# Altium schematic sheet FTDI.SchDoc(FTDI)
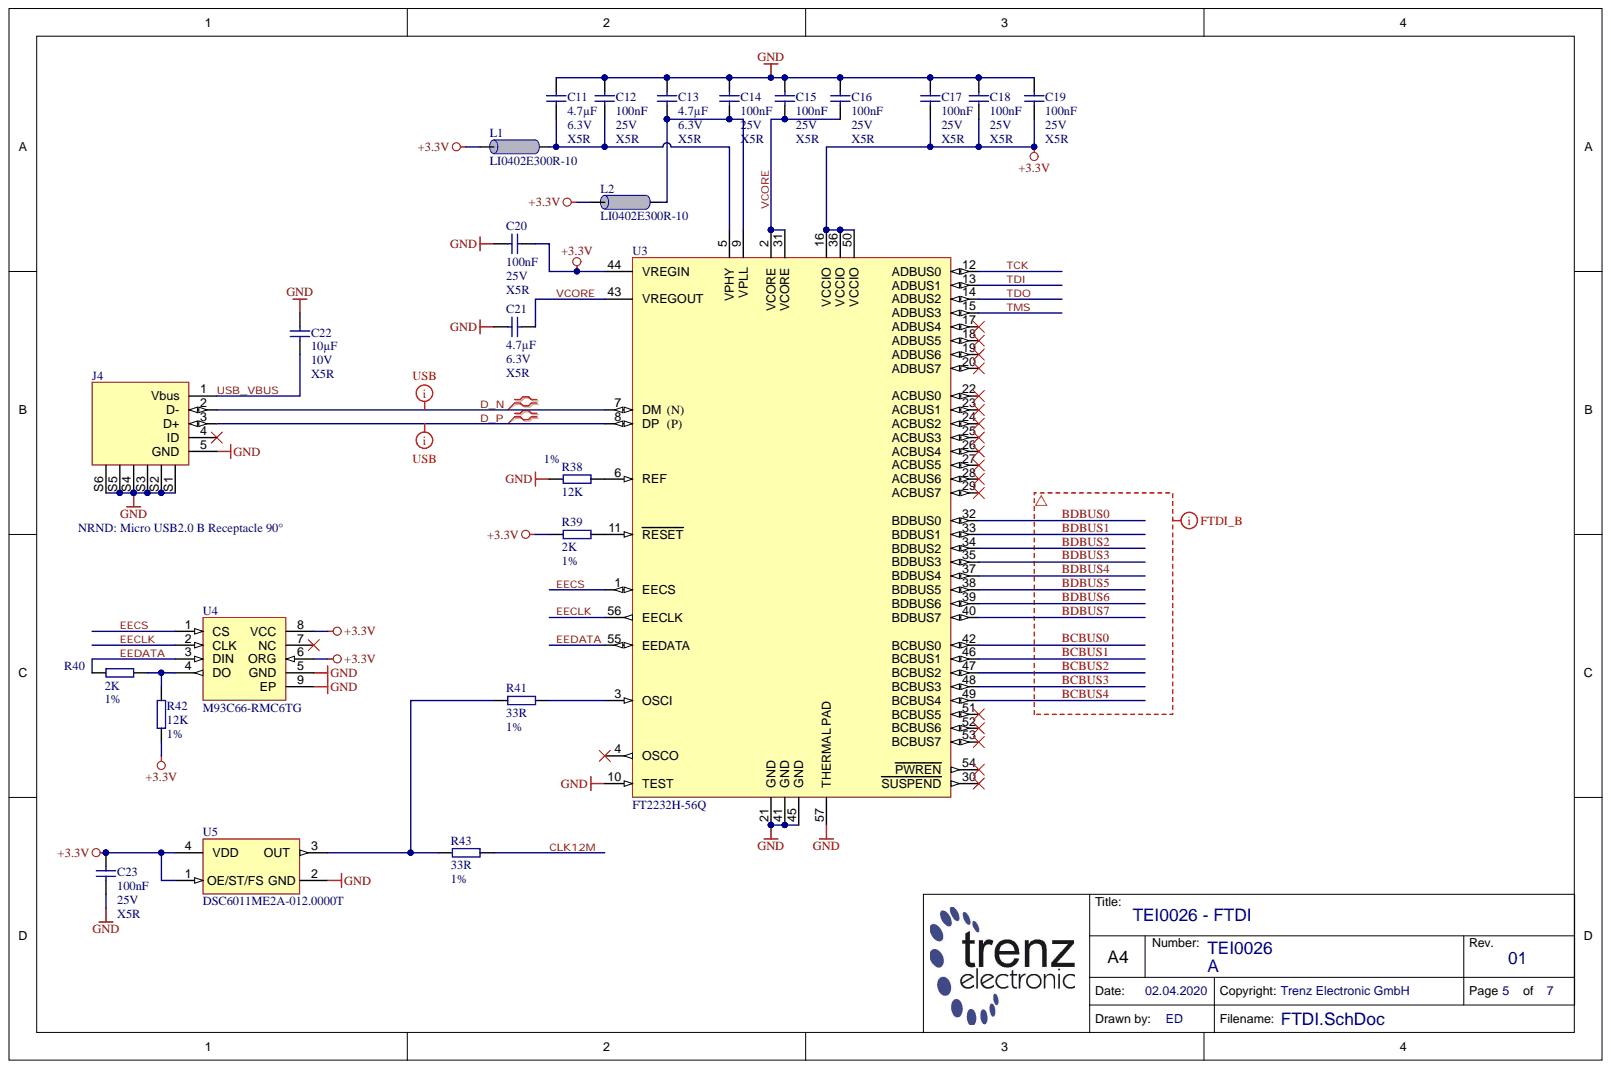
[diagram p0: FTDI.SchDoc]

## Parts
C11  4.7µF  Voltage: 6.3V  Technology: X5R  [CAPC1005X60N]
C12  100nF  Voltage: 25V  Technology: X5R  [CAPC1005X55N]
C13  4.7µF  Voltage: 6.3V  Technology: X5R  [CAPC1005X60N]
C14  100nF  Voltage: 25V  Technology: X5R  [CAPC1005X55N]
C15  100nF  Voltage: 25V  Technology: X5R  [CAPC1005X55N]
C16  100nF  Voltage: 25V  Technology: X5R  [CAPC1005X55N]
C17  100nF  Voltage: 25V  Technology: X5R  [CAPC1005X55N]
C18  100nF  Voltage: 25V  Technology: X5R  [CAPC1005X55N]
C19  100nF  Voltage: 25V  Technology: X5R  [CAPC1005X55N]
C20  100nF  Voltage: 25V  [CAPC1005X55N]
C21  4.7µF  Voltage: 6.3V  Technology: X5R  [CAPC1005X60N]
C22  10µF  Voltage: 10V  Technology: X5R  [CAPC2012X135N]
C23  100nF  Voltage: 25V  Technology: X5R  [CAPC1005X55N]
J4  NRND: Micro USB2.0 B Receptacle 90°  [105017-0001]
L1  LI0402E300R-10  [INDC1005X55N]
L2  LI0402E300R-10  [INDC1005X55N]
R38  12K  Tolerance: 1%  [RESC1005X40N]
R39  2K  Tolerance: 1%  [RESC1005X40N]
R40  2K  Tolerance: 1%  [RESC1005X40N]
R41  33R  Tolerance: 1%  [RESC1005X40N]
R42  12K  Tolerance: 1%  [RESC1005X40N]
R43  33R  Tolerance: 1%  [RESC1005X40N]
U3  FT2232H-56Q  [QFN50P800X800-57T610X610N]
U4  M93C66-RMC6TG  [UFDFPN8]
U5  DSC6011ME2A-012.0000T  [2016]

## Nets
+3.3V: C17.1 C18.1 C19.1 C20.2 C23.2 L1.2 L2.2 R39.1 R42.2 U3.16(VCCIO) U3.36(VCCIO) U3.44(VREGIN) U3.50(VCCIO) U4.6(ORG) U4.8(VCC) U5.1(OE/ST/FS) U5.4(VDD)
GND: C11.2 C12.2 C13.2 C14.2 C15.2 C16.2 C17.2 C18.2 C19.2 C20.1 C21.1 C22.1 C23.1 J4.5(GND) J4.S1 J4.S2 J4.S3 J4.S4 J4.S5 J4.S6 R38.1 U3.10(TEST) U3.21(GND) U3.41(GND) U3.45(GND) U3.57(THERMAL_PAD) U4.5(GND) U4.9(EP) U5.2(GND)
BCBUS0: U3.42(BCBUS0)
BCBUS1: U3.46(BCBUS1)
BCBUS2: U3.47(BCBUS2)
BCBUS3: U3.48(BCBUS3)
BCBUS4: U3.49(BCBUS4)
BDBUS0: U3.32(BDBUS0)
BDBUS1: U3.33(BDBUS1)
BDBUS2: U3.34(BDBUS2)
BDBUS3: U3.35(BDBUS3)
BDBUS4: U3.37(BDBUS4)
BDBUS5: U3.38(BDBUS5)
BDBUS6: U3.39(BDBUS6)
BDBUS7: U3.40(BDBUS7)
CLK12M: R43.2
D_N: J4.2(D-) U3.7(DM)
D_P: J4.3(D+) U3.8(DP)
EECLK: U3.56(EECLK) U4.2(CLK)
EECS: U3.1(EECS) U4.1(CS)
EEDATA: R40.1 U3.55(EEDATA) U4.3(DIN)
TCK: U3.12(ADBUS0)
TDI: U3.13(ADBUS1)
TDO: U3.14(ADBUS2)
TMS: U3.15(ADBUS3)
USB_VBUS: C22.2 J4.1(Vbus)
VCORE: C15.1 C16.1 C21.2 U3.2(VCORE) U3.31(VCORE) U3.43(VREGOUT)
NetC11_1: C11.1 C12.1 L1.1 U3.5(VPHY)
NetC13_1: C13.1 C14.1 L2.1 U3.9(VPLL)
NetR38_2: R38.2 U3.6(REF)
NetR39_2: R39.2 U3.11(RESET)
NetR40_2: R40.2 R42.1 U4.4(DO)
NetR41_1: R41.1 R43.1 U5.3(OUT)
NetR41_2: R41.2 U3.3(OSCI)
N00208:

Not connected: J4 4(ID); U3 4(OSCO) 17(ADBUS4) 18(ADBUS5) 19(ADBUS6) 20(ADBUS7) 22(ACBUS0) 23(ACBUS1) 24(ACBUS2) 25(ACBUS3) 26(ACBUS4) 27(ACBUS5) 28(ACBUS6) 29(ACBUS7) 30(SUSPEND) 51(BCBUS5) 52(BCBUS6) 53(BCBUS7) 54(PWREN); U4 7(NC)

## Library data of the parts
C11 C13 C21 = 24719: KON 4.7µF 6.3V X5R 20% SMD BF 1005 (0402); Value: 4.7u; Temperature-Range: -55/+85; Preferred: Yes; Mixed: SMT; Database Table Name: C_1005_0402; Tolerance: 20%; Library Name: TE.DbLib; Component Kind: Standard; Case: 1005 (0402); AN: 24719
C12 C14-C20 C23 = 22472: KON 100nF 25V X5R ±10% SMD BF 1005 (0402); Value: 100n; Temperature-Range: -55/+85; Preferred: Yes; Mixed: SMT; Database Table Name: C_1005_0402; Library Name: TE.DbLib; Component Kind: Standard; Case: 1005 (0402); AN: 22472
  C20: Technology: X5R
C22 = 28014: KON 10µF 10V X5R 10% SMD BF 2012 (0805); Value: 10u; Temperature-Range: -55/+85; Mixed: SMT; Database Table Name: C_2012_0805; Tolerance: 10%; Library Name: TE.DbLib; Component Kind: Standard; Case: 2012 (0805); AN: 28014
J4 = 27993: Micro USB2.0 B Receptacle 90° SMD/THT; Mixed: SMT; Database Table Name: CON_IO; Library Name: TE.DbLib; H-ART: 105017-0001; Component Kind: Standard; AN: 27993; HelpURL: B:\ad\adlib\datasheet\105017-0001
L1 L2 = 24681: Ferritdurchführung LI0402E300R-10; Voltage: 500mA; Preferred: Yes; Mixed: SMT; Database Table Name: L_1005_0402; Technology: 0.3Ohm; Library Name: TE.DbLib; H-ART: LI0402E300R-10; Component Kind: Standard; Case: 1005 (0402); AN: 24681; HelpURL: B:\AD\adlib\datasheet\LI0402E300R-10
R38 R42 = 22598: WID SMD 12K 1% 0,063W  BF 1005 (0402); Preferred: Yes; Mixed: SMT; Database Table Name: R_1005_0402; Value: 12K; Technology: TK100; Library Name: TE.DbLib; Component Kind: Standard; Case: 1005 (0402); AN: 22598
R39 R40 = 25073: WID SMD 2K 1% 0,063W  BF 1005 (0402); Preferred: Yes; Mixed: SMT; H-ART: RC0402FR-072KL; Database Table Name: R_1005_0402; Value: 2K; Technology: TK100; Library Name: TE.DbLib; Component Kind: Standard; Case: 1005 (0402); AN: 25073
R41 R43 = 22770: WID SMD 33R 1% 0,063W BF 1005 (0402); Value: 33; Preferred: Yes; Mixed: SMT; H-ART: RC0402FR-0733RL; Database Table Name: R_1005_0402; Technology: TK100; Library Name: TE.DbLib; Component Kind: Standard; Case: 1005 (0402); AN: 22770
U3 = 27277: Trenz-Stock: Yes; Temperature-Range: -40/+85; Mixed: SMT; Database Table Name: IF; Storage-Temperature: -65/+150(Abs); Preferred: Yes; Library Name: TE.DbLib; H-ART: FT2232H-56Q; Description: FT2232H-56Q; Component Kind: Standard; Case: VQFN56; AN: 27277; HelpURL: B:\AD\adlib\datasheet\FT2232H
U4 = 27997: Trenz-Stock: Yes; Temperature-Range: -40/+85; Mixed: SMT; Database Table Name: EEPROM; Preferred: Yes; Library Name: TE.DbLib; H-ART: M93C66-RMC6TG; Description: M93C66-RMC6TG; Component Kind: Standard; Case: UFDFPN8; AN: 27997; HelpURL: B:\ad\adlib\datasheet\M93Cx6
U5 = 28002: Value: 12M; Mixed: SMT; Database Table Name: CLK; Library Name: TE.DbLib; H-ART: DSC6011ME2A-012.0000T; Description: DSC6011ME2A-012.0000T; Component Kind: Standard; Case: 2.0 mm x 1.6 mm; AN: 28002; HelpURL: b:\AD\adlib\datasheet\MICROCHIP_DSC60XX\
